# Altium schematic sheet TopSheet.SchDoc(TopSheet)
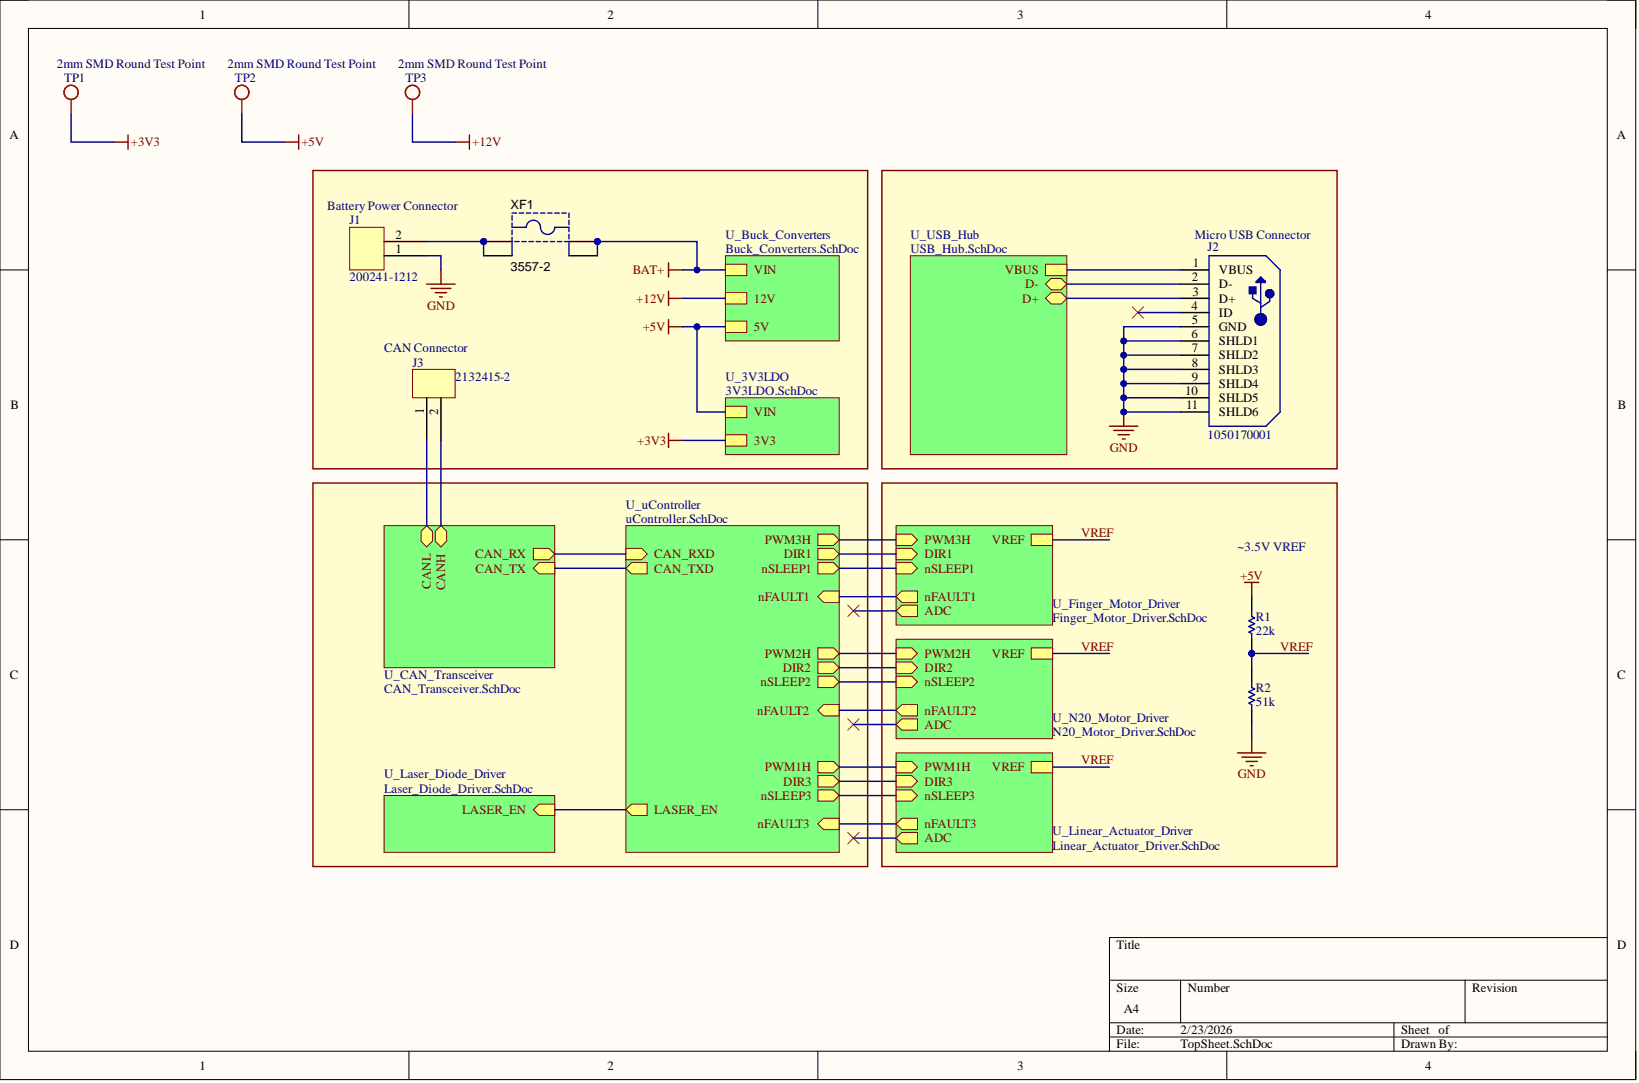
[diagram p0: TopSheet.SchDoc]

## Parts
J1  200241-1212
J2  1050170001  Connector Type: USB
J3  2132415-2
R1  Resistance: 22k  (hidden comment: ERJ-3EKF2202V)
R2  Resistance: 51k  (hidden comment: ERJ-3EKF5102V)
TP1  2mm SMD Round Test Point
TP2  2mm SMD Round Test Point
TP3  2mm SMD Round Test Point
XF1  3557-2

## Nets
+3V3: TP1.0
+5V: R1.2 TP2.0
+12V: TP3.0
BAT+: XF1.3 XF1.4
GND: J1.1 J2.5(GND) J2.6(SHLD1) J2.7(SHLD2) J2.8(SHLD3) J2.9(SHLD4) J2.10(SHLD5) J2.11(SHLD6) R2.1
VREF: R1.1 R2.2
NetJ1_2: J1.2 XF1.1 XF1.2
NetJ2_1: J2.1(VBUS)
NetJ2_2: J2.2(D-)
NetJ2_3: J2.3(D+)
NetJ2_4: J2.4(ID)
NetJ3_1: J3.1
NetJ3_2: J3.2
ADC:
CAN_RX:
CAN_TX:
DIR1:
DIR2:
DIR3:
LASER_EN:
nFAULT1:
nFAULT2:
nFAULT3:
nSLEEP1:
nSLEEP2:
nSLEEP3:
PWM1H:
PWM2H:
PWM3H:

## Library data of the parts
J1 = CMP-002-00085-1: Mega-Fit Power Connector Header 2 Position 5.7mm Solder Right Angle Through Hole; Connector Type: Wire-to-Board; Gender: Female Header; Max Current Rating: 26A; Number of Positions: 2; Voltage Rating: 600V; Case/Package: Mega-Fit Right Angle Header; Component Kind: Standard; Manufacturer Part Number: 200241-1212; Max Operating Temperature: 105°C; Mounting Technology: Through-hole; Pitch: 5.7mm; Library Name: APAC- Monash Nova Rover
J2 = CMP-002-00129-1: Conn Micro USB 2.0 Type B RCP 5 POS 0.65mm Solder RA SMD 5 Terminal 1 Port Micro-USB T/R; Gender: Receptacle; Max Current Rating: 1A; Number of Positions: 5; Voltage Rating: 30V; Component Kind: Standard; Manufacturer Part Number: 1050170001; Max Operating Temperature: 85°C; Mounting Technology: Surface Mount; Pitch: 0.65mm; Library Name: APAC- Monash Nova Rover
J3 = CMP-002-00077-4: Economy Power 2.5 Vertical 2 pin 2132415-2; Connector Type: BOARD CONNECTOR; Max Current Rating: 4.2A; Number of Positions: 2; Pitch: 2.54; Voltage Rating: 250V; Component Kind: Standard; Manufacturer Part Number: 2132415-2; Mounting Technology: TH; Library Name: APAC- Monash Nova Rover
R1 = CMP-00247-1: Res Thick Film 0603 22K Ohm 1% 0.1W ±100ppm/°C Molded SMD Punched Carrier T/R; Package/Case (Imperial): 0603; Power: 100mW; Rated Voltage: 75V; Component Kind: Standard; Composition: Res Thick Film; Manufacturer Part Number: ERJ-3EKF2202V; Mounting Type: SMD; Tolerence: 1%; Library Name: APAC- Monash Nova Rover
R2 = CMP-00244-1: Res Thick Film 0603 51K Ohm 1% 0.1W ±100ppm/°C Molded SMD Punched Carrier T/R; Package/Case (Imperial): 0603; Power: 100mW; Rated Voltage: 75V; Component Kind: Standard; Composition: Res Thick Film; Manufacturer Part Number: ERJ-3EKF5102V; Mounting Type: SMD; Tolerence: 1%; Library Name: APAC- Monash Nova Rover
TP1-TP3 = CMP-00010-4: Component Kind: Standard; Description: 2mm SMD Round Test Point; Manufacturer Part Number: N/A; Library Name: APAC- Monash Nova Rover
XF1 = CMP-00091-1: Up to 30A Blade Fuse Holder; Voltage Rating (DC): 500V; Component Kind: Standard; Current Rating: 30A; Manufacturer Part Number: 3557-2; Library Name: APAC- Monash Nova Rover
